# Altium schematic sheet TFT-LCD.SchDoc(TFT-LCD)
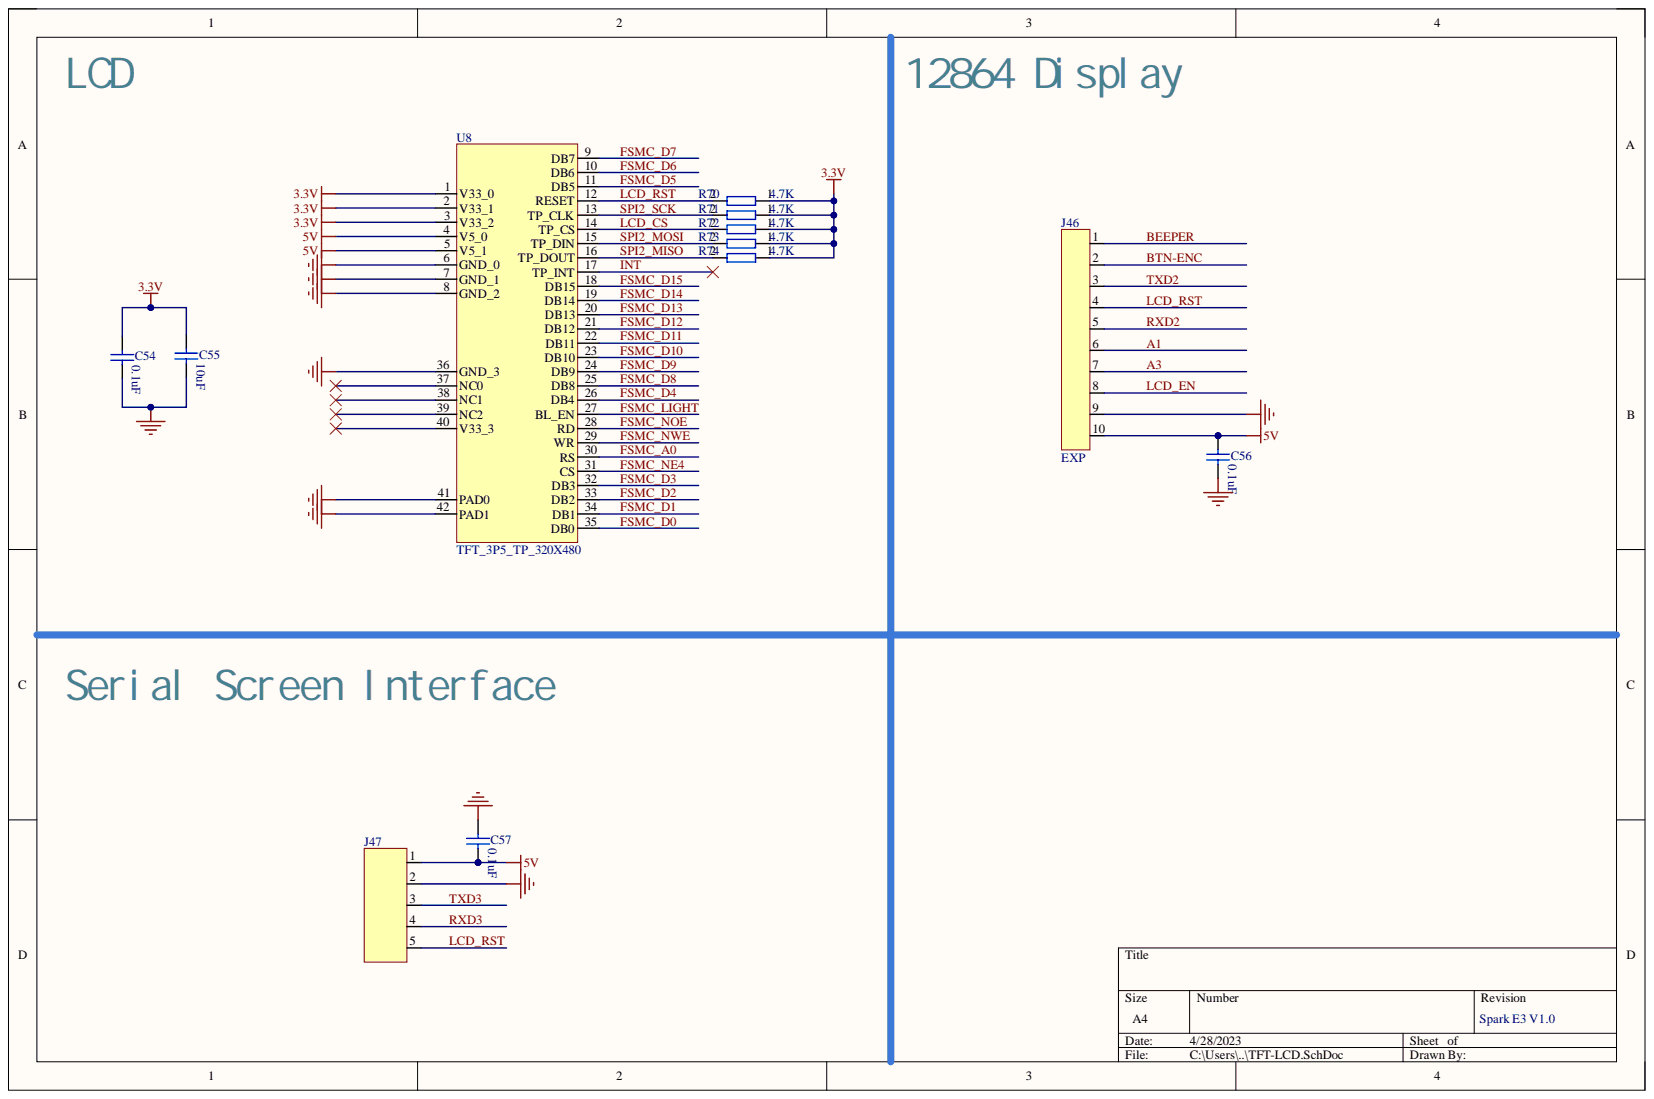
[diagram p0: TFT-LCD.SchDoc]

## Parts
C54  0.1uF  [C0603]
C55  10uF  [C0805]
C56  0.1uF  [C0603]
C57  0.1uF  [C0603]
J46  EXP  [简易牛角-2X5Pin-2.54mm]
J47  Component_1  [DIP/2.54/5P]  (value not drawn)
R70  4.7K  [R0603]
R71  4.7K  [R0603]
R72  4.7K  [R0603]
R73  4.7K  [R0603]
R74  4.7K  [R0603]
U8  TFT_3P5_TP_320X480  [FPC40P-0.5MM]

## Nets
3.3V: C54.1 C55.1 R70.1 R71.1 R72.1 R73.1 R74.1 U8.1(V33_0) U8.2(V33_1) U8.3(V33_2)
5V: C56.1 C57.2 J46.10 J47.1 U8.4(V5_0) U8.5(V5_1)
GND: C54.2 C55.2 C56.2 C57.1 J46.9 J47.2 U8.6(GND_0) U8.7(GND_1) U8.8(GND_2) U8.36(GND_3) U8.41(PAD0) U8.42(PAD1)
A1: J46.6
A3: J46.7
BEEPER: J46.1
BTN-ENC: J46.2
FSMC_A0: U8.30(RS)
FSMC_D0: U8.35(DB0)
FSMC_D1: U8.34(DB1)
FSMC_D2: U8.33(DB2)
FSMC_D3: U8.32(DB3)
FSMC_D4: U8.26(DB4)
FSMC_D5: U8.11(DB5)
FSMC_D6: U8.10(DB6)
FSMC_D7: U8.9(DB7)
FSMC_D8: U8.25(DB8)
FSMC_D9: U8.24(DB9)
FSMC_D10: U8.23(DB10)
FSMC_D11: U8.22(DB11)
FSMC_D12: U8.21(DB12)
FSMC_D13: U8.20(DB13)
FSMC_D14: U8.19(DB14)
FSMC_D15: U8.18(DB15)
FSMC_LIGHT: U8.27(BL_EN)
FSMC_NE4: U8.31(CS)
FSMC_NOE: U8.28(RD)
FSMC_NWE: U8.29(WR)
INT: U8.17(TP_INT)
LCD_CS: R72.2 U8.14(TP_CS)
LCD_EN: J46.8
LCD_RST: J46.4 J47.5 R70.2 U8.12(RESET)
RXD2: J46.5
RXD3: J47.4
SPI2_MISO: R74.2 U8.16(TP_DOUT)
SPI2_MOSI: R73.2 U8.15(TP_DIN)
SPI2_SCK: R71.2 U8.13(TP_CLK)
TXD2: J46.3
TXD3: J47.3

Not connected: U8 37(NC0) 38(NC1) 39(NC2) 40(V33_3)

## Library data of the parts
C54-C57 = 电容: Component Kind: Standard; LatestRevisionDate: 17-Jul-2002; LatestRevisionNote: Re-released for DXP Platform.; PackageDescription: Radial Cap, Thru-Hole; 2 Leads; 0.3 in Pin Spacing; PackageReference: RAD-0.3; Published: 8-Jun-2000; Signal Integrity: Cap; Simulation: CAP; Value: ?; Library Name: MYschlib.SchLib; Publisher: Altium Limited
J46 = Component_1: Component Kind: Standard; Library Name: Schlib1.SchLib
R70-R74 = 电阻: Component Kind: Standard; value: ?; Library Name: MYschlib.SchLib
J47 = Component_1: Component Kind: Standard; Library Name: Schlib1.SchLib
U8 = TFT_3P5_TP_320X480: Component Kind: Standard; Library Name: jietai-ADLB.SchLib
